# Altium schematic sheet FontesLineares.SchDoc(FontesLineares)
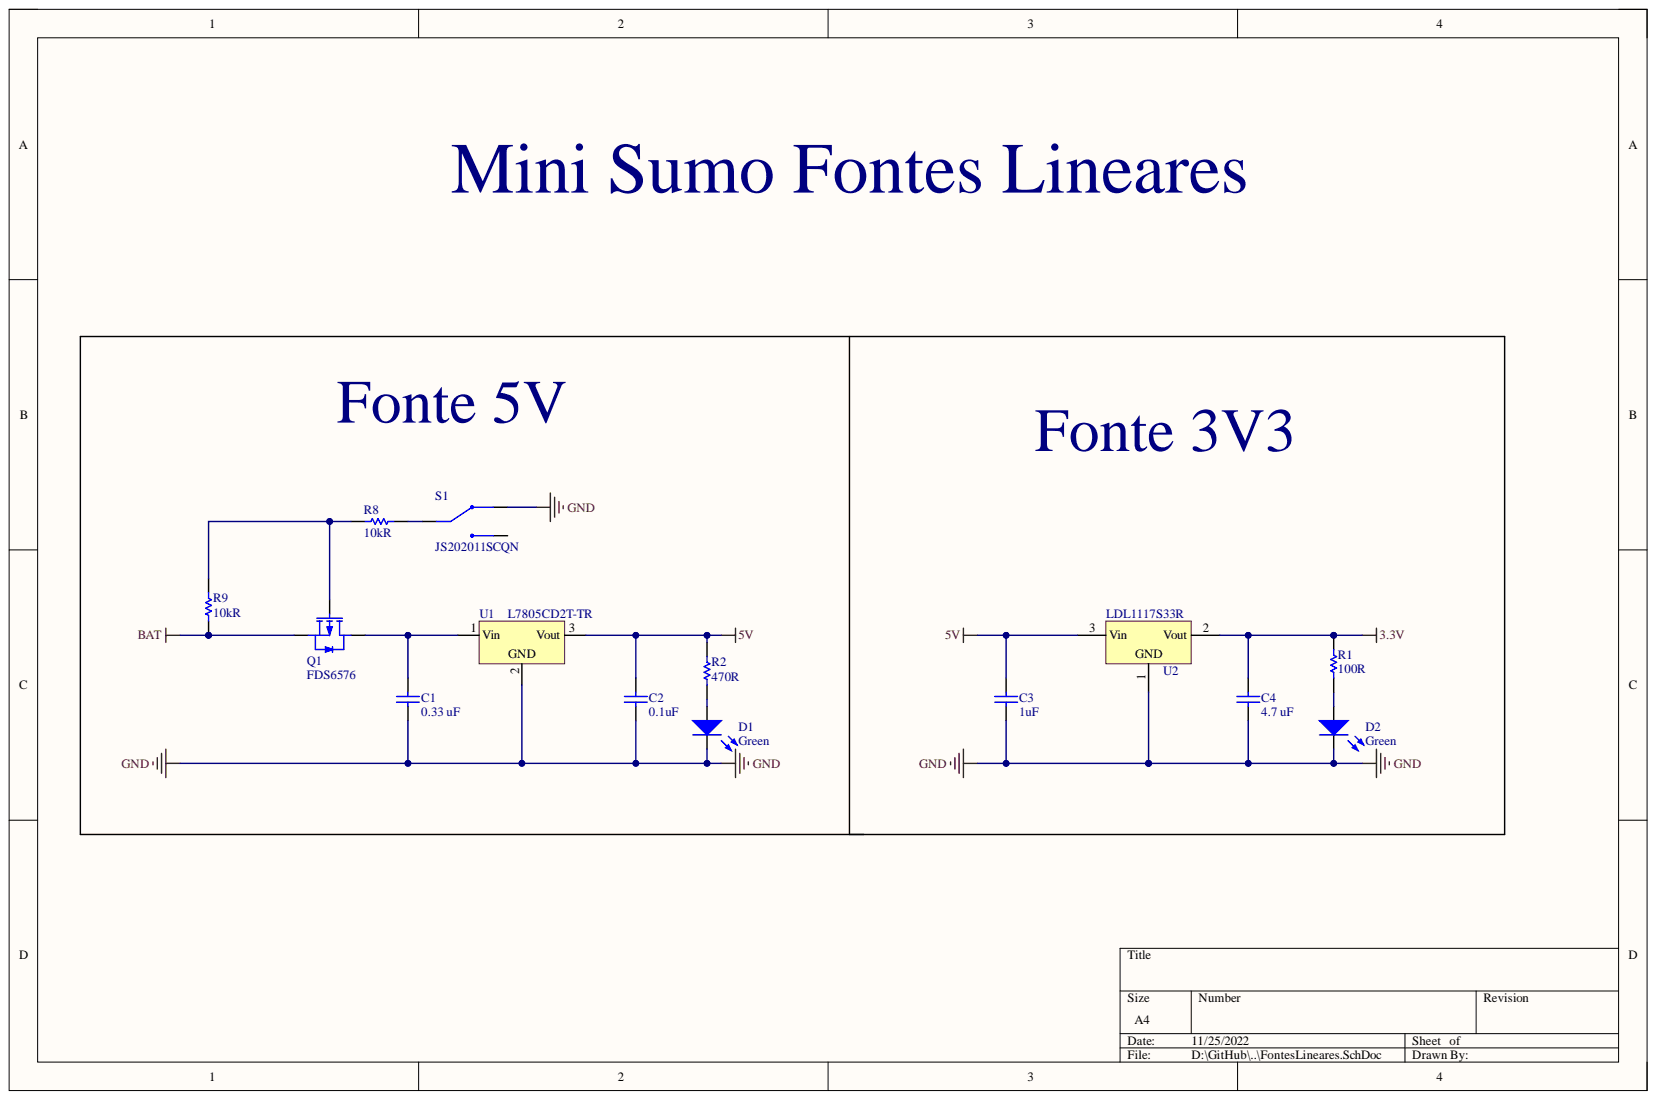
[diagram p0: FontesLineares.SchDoc]

## Parts
C1  0.33 uF  [C0805]
C2  0.1uF  [C0805]
C3  1uF  [C0603]
C4  4.7 uF  [C0805]
D1  Green  [LED_0603]
D2  Green  [LED_0603]
Q1  FDS6576  [SOIC-8]
R1  100R  [RESISTOR_0805]
R2  470R  [RESISTOR_0805]
R8  10kR  [RESISTOR_0603]
R9  10kR  [RESISTOR_0603]
S1  JS202011SCQN  [DPDT]
U1  L7805CD2T-TR  [D2PAK]
U2  LDL1117S33R  [SOT-223-4]

## Nets
3.3V: C4.2 R1.2 U2.2
5V: C2.2 C3.2 R2.2 U1.3 U2.3
BAT: Q1.S R9.1
GND: C1.1 C2.1 C3.1 C4.1 D1.C D2.C S1.A2 U1.2 U2.1
NetC1_2: C1.2 Q1.D U1.1
NetD1_A: D1.A R2.1
NetD2_A: D2.A R1.1
NetQ1_G: Q1.G R8.2 R9.2
NetR8_1: R8.1 S1.A1

Not connected: S1 A3

## Library data of the parts
C1 = GCM21BR71E334KA37K: CAP CER 0.33UF 25V X7R 0805; Manufacturer: Murata Eletronics; Library Control - Checked Footprint: Yes; Library Control - Person that Checked Footprint: Nikaum; Tolerance: 10%; Voltage - Rated: 25 VDC; Category: Ceramic Capacitor; Component Kind: Standard; ComponentLink1Description: Datasheet; ComponentLink1URL: https://br.mouser.com/datasheet/2/281/GCM21BR71E334KA37_01-1968656.pdf; ComponentLink2Description: Mouser Link; ComponentLink2URL: https://br.mouser.com/ProductDetail/Murata-Electronics/GCM21BR71E334KA37K?qs=N3Kl9KD794Tg%2FXM5q2uFQQ%3D%3D; ComponentLink3Description: ESR; ComponentLink3URL: https://www.murata.com/en-us/products/productdetail?partno=GCM21BR71E334KA37%23; Dieletric: X7R; Library Control - Checked Schematic: Yes; Library Control - Last Update: 28/06/2021 00:57; Library Ref: Capacitor; Operating Temperature: -55 C ~ 125 C; Package / Case: 0805 (2012 Metric); Part Number: GCM21BR71E334KA37K; Price: 1= 0,57, 10= 0,398, 100= 0,239 (USD); Size / Dimension: 2,00 L x 1,25 W x 1,25 H; Supplier 1: Mouser; Supplier Part Number 1: 81-GCM21BR71E334KA7K; Value: 0.33 uF; Library Control - Person that Checked Schematic: Nikaum; Library Control - Person that did the Last Update Footprint: Nikaum; Library Control - Person that did the Last Update Schematic: Nikaum; Library Name: Uai!Tecas.IntLib
C2 = C0805C104M3RACTU: CAP CER 0.1uF 25V X7R 0805; Manufacturer: Kemet; Library Control - Checked Footprint: No; Library Control - Checked Schematic: Yes; Library Control - Person that Checked Schematic: Guilherme; Library Control - Person that did the Last Update Schematic: Marcos; Tolerance: 20%; Voltage - Rated: 25 VDC; Category: Ceramic Capacitor; Component Kind: Standard; ComponentLink1Description: Datasheet; ComponentLink1URL: https://br.mouser.com/datasheet/2/212/KEM_C1002_X7R_SMD-1102033.pdf; ComponentLink2Description: Mouser Link; ComponentLink2URL: https://br.mouser.com/ProductDetail/KEMET/C0805C104M3RACTU?qs=jbCUrP3sAgSIdMuvv9W4Pg%3D%3D; Dieletric: X7R; ESR: -; Library Control - Last Update: 26/06/2021 20:52; Library Ref: Capacitor; Operating Temperature: -55 C ~ 125 C; Package / Case: 0805(2012 mestric); Part Number: C0805C104M3RACTU; Price: 1=0,13 10=0,064 100=0,037 1000=0,025 (USD); Size / Dimension: 1.25 mm W x 2 mm L x 0.78 mm H; Supplier 1: Mouser; Supplier Part Number 1: 80-C0805C104M3R; Value: 0.1uF; Library Name: Uai!Tecas.IntLib
C3 = Capacitor Model: CAP CER 1UF 25V X7R 0603; Component Kind: Standard; ComponentLink1Description: Mouser Link; ComponentLink2Description: Datasheet; Value: 1uF; Library Name: Uai!Tecas.IntLib
C4 = GRM219R61E475KA73D: CAP CER 4.7uF 25V X5R 0805; Manufacturer: MURATA ELECTRONICS; Library Control - Checked Footprint: Yes; Library Control - Person that Checked Footprint: Cage; Tolerance: 10%; Voltage - Rated: 25 VDC; Category: Ceramic Capacitors; Component Kind: Standard; ComponentLink1Description: Datasheet; ComponentLink1URL: https://br.mouser.com/datasheet/2/281/1/GRM219R61E475KA73_01A-1986363.pdf; ComponentLink2Description: Mouser Link; ComponentLink2URL: https://br.mouser.com/ProductDetail/Murata-Electronics/GRM219R61E475KA73D?qs=%2Fha2pyFadui1540apPxqrcvNL2Od6%2FE9q78FE8iyERiL9ZO4NhnlONCgyc%2FC2rEQ; Dieletric: X5R; ESR: -; Library Control - Checked Schematic: Yes; Library Control - Last Update: 25/08/22; Library Ref: Capacitor; Operating Temperature: -55°C ~ 85°C; Package / Case: 0805(2012 metric); Part Number: GRM219R61E475KA73D; Price: 1=0,28 10=0,193 100=0,098 1000=0,059 (USD); Size / Dimension: 2 mm L x 1.25 mm W x 0.85 mm H; Supplier 1: Mouser; Supplier Part Number 1: 81-GRM219R61E475KA73D; Value: 4.7 uF; Library Control - Person that Checked Schematic: Cage; Library Control - Person that did the Last Update Footprint: Cage; Library Control - Person that did the Last Update Schematic: Cage; Library Name: Uai!Tecas.IntLib
D1 D2 = 150060VS75000: LED 72mW GREEN 40MCD 0603; Manufacturer: Wurth Elektronik; Current - Forward (If): 20 mA; Library Control - Last Project that Used: Diodo/Led; Library Control - Person that Checked Footprint: Stéfany (Ster); Library Control - Person that did the Last Update Schematic: Marcos; Max Current Rating: 100mA; Reverse Voltage: 5V; Viewing Angle: 140°; Voltage - Forward (Vf): 2 V; Wavelength: 570nm; Category: Standard LEDs - SMD; Color: Green; Component Kind: Standard; ComponentLink1Description: Mouser Link; ComponentLink1URL: https://br.mouser.com/ProductDetail/Wurth-Elektronik/150060VS75000?qs=%2Fha2pyFaduhuT%2FKGSijZP0vTyJZ9U993KfsBQSxqATYhY9jnc2c09tXoMoJ3wn4L; ComponentLink2Description: Datasheet Link; ComponentLink2URL: https://br.mouser.com/datasheet/2/445/150060VS75000-1714627.pdf; Library Control - Checked Footprint: 25/07/2021; Library Control - Checked Schematic: 25/07/2021; Library Control - Last Update: 09/09/2021 16:12; Library Ref: LED; Luminous Intensity: 40 mcd; Max Power Dissipation: 72mW; Operating Temperature: -40~85 °C; Package / Case: 0603; Part Number: 150060VS75000; Price: 1 = 0.14, 50 = 0.133, 100 = 0.114 (USD); Supplier 1: Mouser; Supplier Part Number 1: 710-150060VS75000; Library Control - Person that Checked Schematic: Stéfany (Ster); Library Control - Person that did the Last Update Footprint: Stéfany (Ster); Library Name: Uai!Tecas.IntLib
Q1 = FDS6576: MOSFET P-CH 20V 11A SOIC-8; Manufacturer: onsemi / Fairchild; Current - Continuous Drain (Id) @25°C: -11A; Drain to Source Voltage (Vds): -20V; Drive Voltage (Max Rds On, Min Rds On): -2.5V ~4.5V; FET Type: P-Channel; Gate Charge (Qg) (Max) @ Vgs: 60nC@-4.5V; Input Capacitance (Ciss) (Max) @Vds: 4044pF@-10V; Power Dissipation (Max): 2.5W; Rds On (Max) @ Id, Vgs: 23mOhms @ -11A, -4.5V; Rise / Fall Time (Typ): 17 / 79 (ns); Vgs (Max): 12V; Vgs(th) (Max) Id: -1.5V@-250uA; Component Kind: Standard; ComponentLink1Description: Datasheet; ComponentLink1URL: https://br.mouser.com/datasheet/2/308/1/FDS6576_D-1808687.pdf; ComponentLink2Description: Mouser; ComponentLink2URL: https://br.mouser.com/ProductDetail/onsemi-Fairchild/FDS6576?qs=FOlmdCx%252BAA1N14vq5QZP7Q%3D%3D; Library Ref: Mosfet; Operating Temperature: -55 °C ~150 °C; Package / Case: SOIC-8; Part Number: SOIC-8; Price: 1=1.34, 10=1.20, 100=0.932, 500=0.77, 1000=0.608 (USD); Supplier 1: Mouser; Supplier Part Number 1: 512-FDS6576; Library Name: Uai!Tecas.IntLib
R1 = CRCW0805100RFKEA: RES SMD 100 OHM 1% 1/8W 0805; Manufacturer: Vishay / Dale; Library Control - Checked Footprint: No; Library Control - Checked Schematic: Yes; Library Control - Person that Checked Schematic: Marcos; Library Control - Person that did the Last Update Footprint: Dalarme; Power Rating: 125 mW (1/8 W); Temperature Coefficient: 100 PPM / C; Voltage Rating: 150 V; Category: Thick Film Resistors - SMD; Component Kind: Standard; ComponentLink1Description: Mouser Link; ComponentLink1URL: https://www.mouser.com/ProductDetail/Vishay-Dale/CRCW0805100RFKEA?qs=sGAEpiMZZMu61qfTUdNhG2DpbjADlD3GxPcNG3UnvYk%3D; ComponentLink2Description: Datasheet Link; ComponentLink2URL: https://www.mouser.com/datasheet/2/427/dcrcwe3-1762152.pdf; Library Control - Last Update: 20/06/21 16:56; Library Ref: Resistor; Operating Temperature: - 55ºC ~ 155ºC; Package / Case: 0805 (2012 Metric); Part Number: CRCW0805100RFKEA; Price: 1=0,18, 10=0,065, 100=0,027, 1000=0,012 (USD); Resistor Type: Fixo; Size / Dimension: 2 mm L x  1.25 mm W x  0.45 mm H; Supplier 1: Mouser; Supplier Part Number 1: 71-CRCW0805-100-E3; Tolerance: 1 %; Value: 100R; Library Control - Person that did the Last Update Schematic: Marcos; Library Name: Uai!Tecas.IntLib
R2 = ERJ-6GEYJ471V: RES SMD 470 OHM 5% 1/8W 0805; Manufacturer: Panasonic; Part Number: 667-ERJ-6GEYJ471V; Library Control - Checked Footprint: No; Library Control - Checked Schematic: Yes; Library Control - Person that Checked Schematic: Marcos; Library Control - Person that did the Last Update Footprint: Dalarme; Power Rating: 125 mW (1/8 W); Temperature Coefficient: 200 PPM / C; Voltage Rating: 150 V; Category: Thick Film Resistors - SMD; Component Kind: Standard; ComponentLink1Description: Datasheet Link; ComponentLink1URL: https://br.mouser.com/datasheet/2/315/AOA0000C301-1488782.pdf; ComponentLink2Description: Mouser Link; ComponentLink2URL: https://www.mouser.com/ProductDetail/Panasonic/ERJ-6GEYJ471V?qs=%2Fha2pyFadujWqMGonbcVXQOcxwQBA8OuqUzw3XmrY6vv5LW7wYDrug%3D%3D; Library Control - Last Update: 20/06/21 16:06; Library Ref: Resistor; Operating Temperature: - 55ºC ~ 155ºC; Package / Case: 0805 (2012 Metric); Price: 1=0,1000, 10=0,04300, 100=0,02000 1000 = 0,009(USD); Resistor Type: Fixo; Size / Dimension: 2 mm L x  1.25 mm W x  0.6 mm H; Supplier 1: Mouser; Supplier Part Number 1: 667-ERJ-6GEYJ471V; Tolerance: 5 %; Value: 470R; Library Control - Person that did the Last Update Schematic: Marcos; Library Name: Uai!Tecas.IntLib
R8 R9 = RC0603JR-0710KL: RES SMD 10K OHM 5% 1/10W 0603; Manufacturer: Yageo; Library Control - Checked Footprint: No; Library Control - Checked Schematic: Yes; Library Control - Person that Checked Schematic: Marcos; Library Control - Person that did the Last Update Footprint: Dalarme; Power Rating: 100 mW (1/10 W); Temperature Coefficient: 100 PPM / C; Voltage Rating: 75 V; Category: Thick Film Resistors - SMD; Component Kind: Standard; ComponentLink1Description: Mouser Link; ComponentLink1URL: https://br.mouser.com/ProductDetail/Yageo/RC0603JR-0710KL?qs=ksOUTF%2FWcd8D6T22BJkQ7g%3D%3D; ComponentLink2Description: Datsheet Link; ComponentLink2URL: https://br.mouser.com/datasheet/2/447/Yageo_03_18_2021_PYu_RC_Group_51_RoHS_L_11-2199992.pdf; Library Control - Last Update: 21/06/21 14:15; Library Ref: Resistor; Operating Temperature: - 55ºC ~ 155ºC; Package / Case: 0603 (1608 Metric); Part Number: RC0603JR-0710KL; Price: 1=0,10, 10=0,015, 100=0,005 1000=0,0040 (USD); Resistor Type: Fixo; Size / Dimension: 1.6 mm L x  0.8 mm W x  0.45 mm H; Supplier 1: Mouser; Supplier Part Number 1: 603-RC0603JR-0710KL; Tolerance: 5 %; Value: 10kR; Library Control - Person that did the Last Update Schematic: Marcos; Library Name: Uai!Tecas.IntLib
S1 = JS202011SCQN: DEEP SWITCH 4 POSITIONS; Manufacturer: C&K; Current Rating: 300 mA; Library Control - Checked Footprint: Yes; Library Control - Person that Checked Footprint: Nikaum; Switch Function: ON - ON; Voltage Rating DC: 30 VDC; Component Kind: Standard; ComponentLink1Description: Mouser Link; ComponentLink1URL: https://br.mouser.com/ProductDetail/CK/JS202011SCQN?qs=LgMIjt8LuD95JYWxZ7NvZA%3D%3D; ComponentLink2Description: Datasheet Link; ComponentLink2URL: https://www.mouser.com/datasheet/2/60/js-1841892.pdf; Library Control - Checked Schematic: Yes; Library Control - Last Update: 15/06/2021; Library Ref: Diversos; Mouser Part Number: 611-JS202011SCQN; Operating Temperature(max - min): -20~70°C; Part Number: JS202011SCQN; Supplier: Mouser; Library Control - Person that Checked Schematic: Nikaum; Library Control - Person that did the Last Update Footprint: Nikaum; Library Control - Person that did the Last Update Schematic: Nikaum; Library Name: Diversos.SchLib
U1 = L7805CD2T-TR: IC REG LINEAR 5V 1A D2PAK; Manufacturer: ST; Current - Quiescent (Iq): 8mA; Library Control - Checked Footprint: Yes; Library Control - Person that Checked Footprint: Nícolas; Max Power Dissipation: Internally Limited; Number of Regulators: 1; Output Type: Fixed; Voltage - Dropout (Max): 2V; Voltage - Input (Max): 35V; Voltage - Output (Max): 5.25V; Category: Linear Voltage Regulator; Component Kind: Standard; ComponentLink1Description: Mouser Link; ComponentLink1URL: https://br.mouser.com/ProductDetail/STMicroelectronics/L7805CD2T-TR?qs=%2Fha2pyFadujE9KQ1BxQHaLKqcK8XYkwQA8UfL%2FIGb8dfQq%2Fq%2FT%2Fjqg%3D%3D; ComponentLink2Description: Datasheet; ComponentLink2URL: https://br.mouser.com/datasheet/2/389/cd00000444-1795274.pdf; Current - Output: 1A; Library Control - Checked Schematic: Yes; Library Control - Last Update: 25/06/21; Library Ref: Voltage Regulator; Operating Temperature: -40~125 ºC; Package/ Case: D2PAK; Part Number: L7805CD2T-TR; Power Dissipation: Internally Limited; Price: 1=0,93, 10=0,83, 100=0,79 (USD); Supplier 1: Mouser; Supplier Part Number 1: 511-L7805CD2T-TR; Voltage - Output (Min/Fixed): 5V; Library Control - Person that Checked Schematic: Nícolas; Library Control - Person that did the Last Update Schematic: Nícolas; Library Name: Uai!Tecas.IntLib
U2 = LDL1117S33R: IC REG LINEAR 3.3V 1.2A SOT-223-4; Manufacturer: STMicroelectronics; Current - Quiescent (Iq): 250 uA; Library Control - Checked Footprint: Yes; Library Control - Person that Checked Footprint: Nícolas; Library Control - Person that did the Last Update Footprint: Pierre; Max Power Dissipation: Internally limited; Number of Regulators: 1; Output Type: Fixed; Voltage - Dropout (Max): 600mV (1.2 A); Voltage - Input (Max): 18V; Voltage - Output (Max): 3.6V; Category: Linear Voltage Regulator; Component Kind: Standard; ComponentLink1Description: Mouser Link; ComponentLink1URL: https://br.mouser.com/ProductDetail/STMicroelectronics/LDL1117S33R?qs=%2Fha2pyFadugA91l4ky%2FRZ5SN3GrMJ6YI2MUHTqmRDLfkBVrFdA%252BW6Q%3D%3D; ComponentLink2Description: Datasheet; ComponentLink2URL: https://br.mouser.com/datasheet/2/389/dm00366442-1799212.pdf; Current - Output: 1.2 A; Library Control - Checked Schematic: Yes; Library Control - Last Update: 23/06/21 10:22; Library Ref: Voltage Regulator; Operating Temperature: -40°C~125°C; Package/ Case: SOT-223-4; Part Number: LDL1117S33R; Power Dissipation: Internally limited; Price: 1= 0.46000, 10= 0.34000, 100= 0.22900 (USD); Supplier 1: Mouser; Supplier Part Number 1: 511-LDL1117S33R; Voltage - Output (Min/Fixed): 3.3 V; Library Control - Person that Checked Schematic: Nícolas; Library Control - Person that did the Last Update Schematic: Nícolas; Library Name: Uai!Tecas.IntLib
